# Altium schematic sheet SWITCHING (CONT).SchDoc
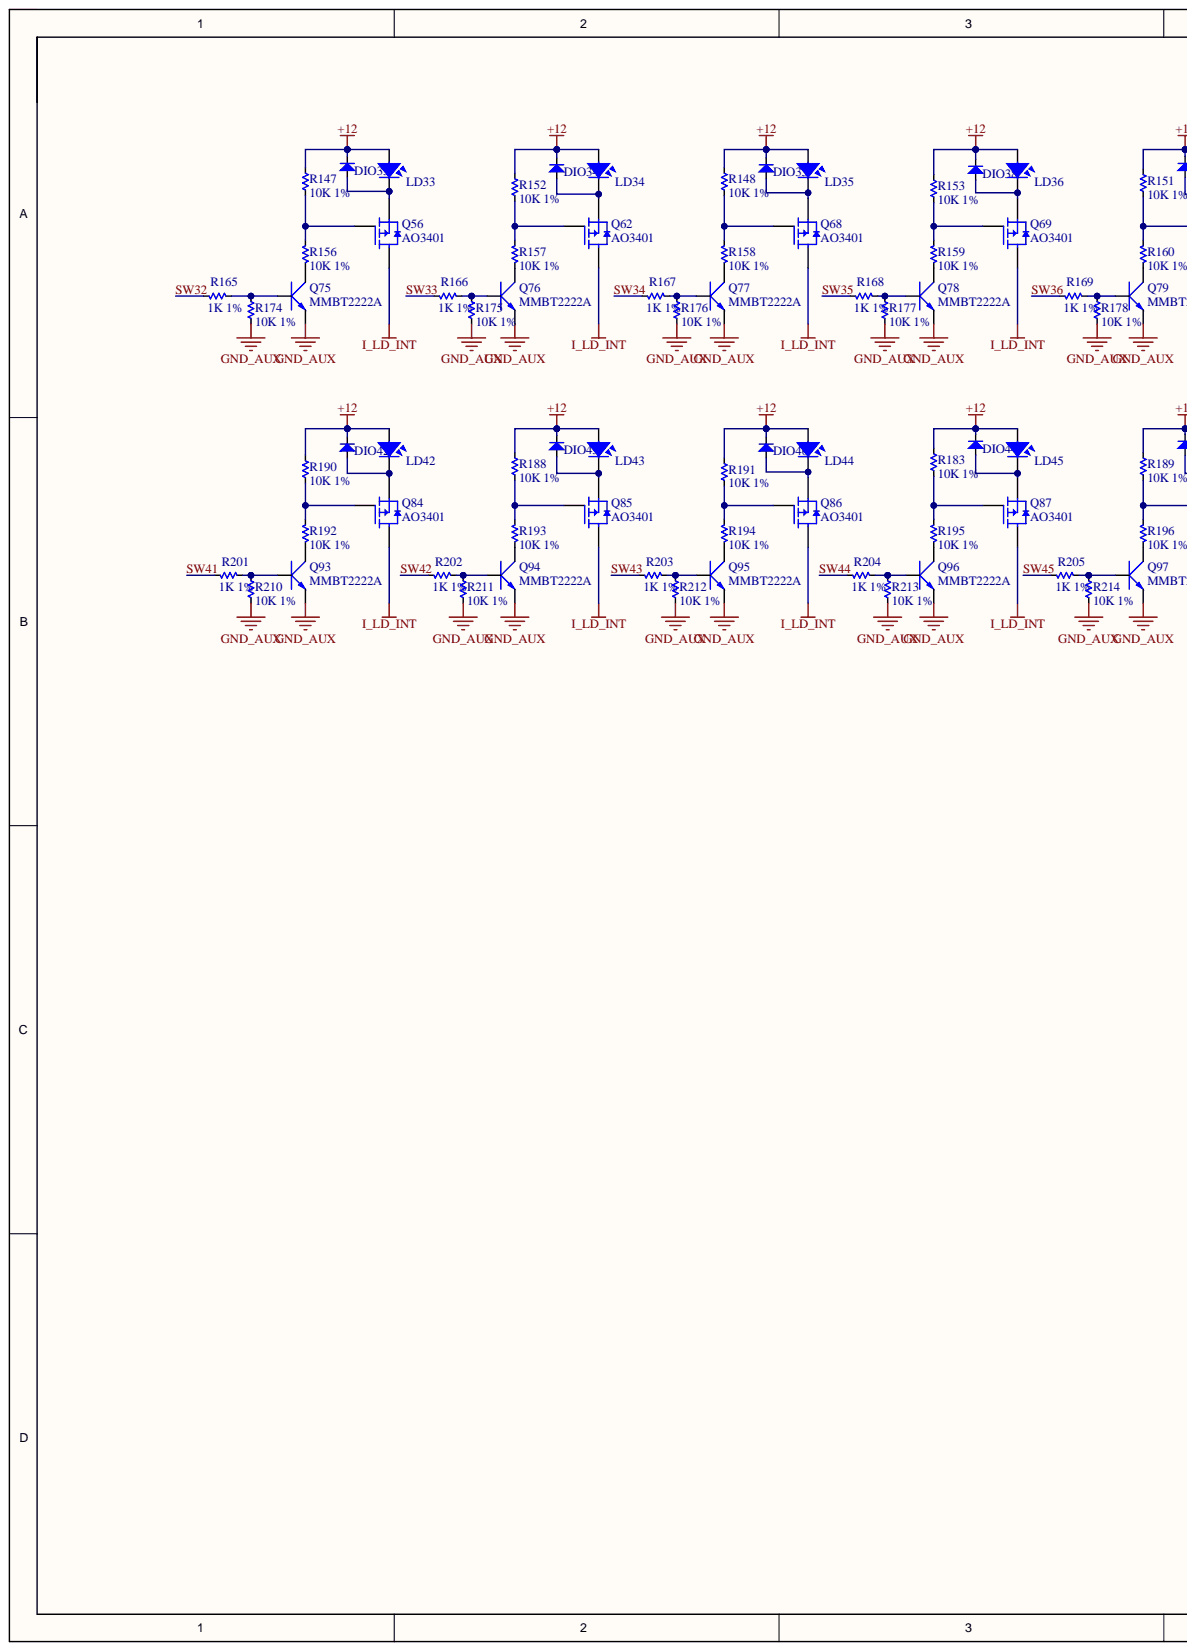
[diagram p0: SWITCHING (CONT).SchDoc, left]
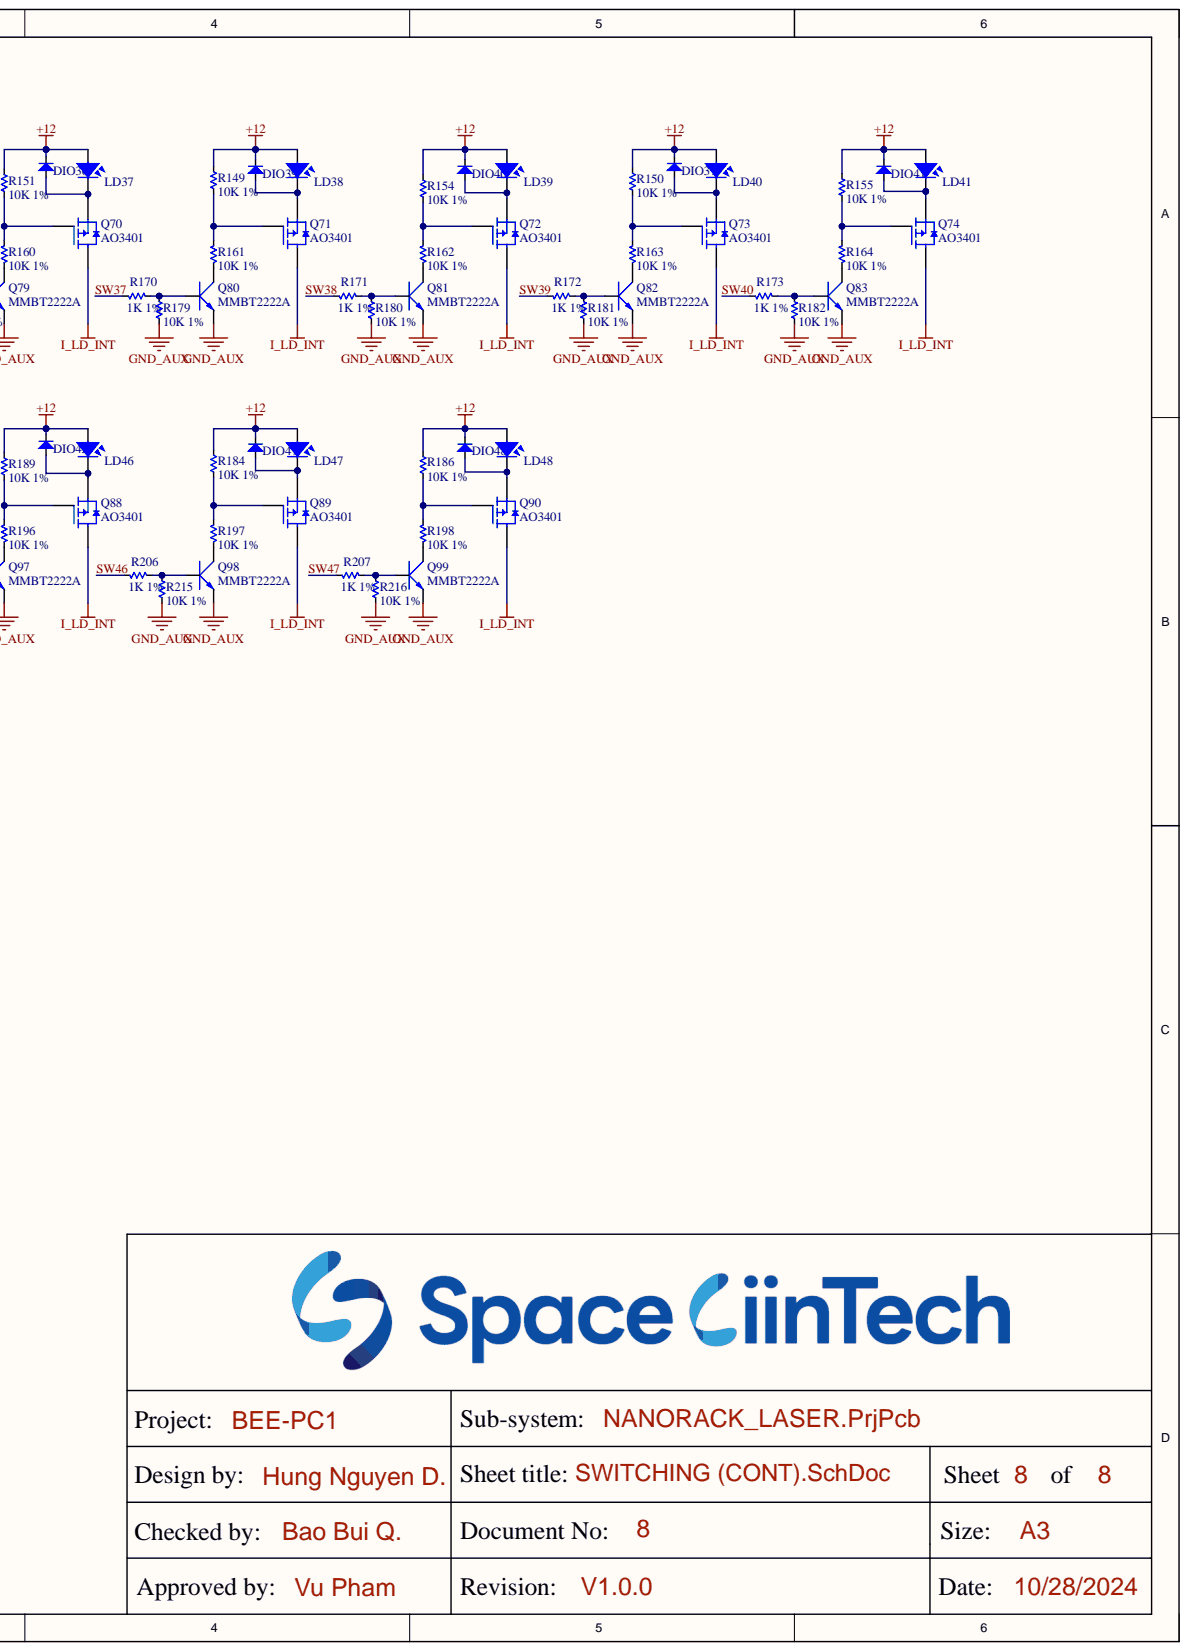
[diagram p1: SWITCHING (CONT).SchDoc, right]

## Parts
DIO33  Diode SMD  [SOD23]  (value not drawn)
DIO34  Diode SMD  [SOD23]  (value not drawn)
DIO35  Diode SMD  [SOD23]  (value not drawn)
DIO36  Diode SMD  [SOD23]  (value not drawn)
DIO37  Diode SMD  [SOD23]  (value not drawn)
DIO38  Diode SMD  [SOD23]  (value not drawn)
DIO39  Diode SMD  [SOD23]  (value not drawn)
DIO40  Diode SMD  [SOD23]  (value not drawn)
DIO41  Diode SMD  [SOD23]  (value not drawn)
DIO42  Diode SMD  [SOD23]  (value not drawn)
DIO43  Diode SMD  [SOD23]  (value not drawn)
DIO44  Diode SMD  [SOD23]  (value not drawn)
DIO45  Diode SMD  [SOD23]  (value not drawn)
DIO46  Diode SMD  [SOD23]  (value not drawn)
DIO47  Diode SMD  [SOD23]  (value not drawn)
DIO48  Diode SMD  [SOD23]  (value not drawn)
LD33  LaserDiode  [LASER]  (value not drawn)
LD34  LaserDiode  [LASER]  (value not drawn)
LD35  LaserDiode  [LASER]  (value not drawn)
LD36  LaserDiode  [LASER]  (value not drawn)
LD37  LaserDiode  [LASER]  (value not drawn)
LD38  LaserDiode  [LASER]  (value not drawn)
LD39  LaserDiode  [LASER]  (value not drawn)
LD40  LaserDiode  [LASER]  (value not drawn)
LD41  LaserDiode  [LASER]  (value not drawn)
LD42  LaserDiode  [LASER]  (value not drawn)
LD43  LaserDiode  [LASER]  (value not drawn)
LD44  LaserDiode  [LASER]  (value not drawn)
LD45  LaserDiode  [LASER]  (value not drawn)
LD46  LaserDiode  [LASER]  (value not drawn)
LD47  LaserDiode  [LASER]  (value not drawn)
LD48  LaserDiode  [LASER]  (value not drawn)
Q56  AO3401  [SOT23-123]
Q62  AO3401  [SOT23-123]
Q68  AO3401  [SOT23-123]
Q69  AO3401  [SOT23-123]
Q70  AO3401  [SOT23-123]
Q71  AO3401  [SOT23-123]
Q72  AO3401  [SOT23-123]
Q73  AO3401  [SOT23-123]
Q74  AO3401  [SOT23-123]
Q75  MMBT2222A  [SOT23-132]
Q76  MMBT2222A  [SOT23-132]
Q77  MMBT2222A  [SOT23-132]
Q78  MMBT2222A  [SOT23-132]
Q79  MMBT2222A  [SOT23-132]
Q80  MMBT2222A  [SOT23-132]
Q81  MMBT2222A  [SOT23-132]
Q82  MMBT2222A  [SOT23-132]
Q83  MMBT2222A  [SOT23-132]
Q84  AO3401  [SOT23-123]
Q85  AO3401  [SOT23-123]
Q86  AO3401  [SOT23-123]
Q87  AO3401  [SOT23-123]
Q88  AO3401  [SOT23-123]
Q89  AO3401  [SOT23-123]
Q90  AO3401  [SOT23-123]
Q93  MMBT2222A  [SOT23-132]
Q94  MMBT2222A  [SOT23-132]
Q95  MMBT2222A  [SOT23-132]
Q96  MMBT2222A  [SOT23-132]
Q97  MMBT2222A  [SOT23-132]
Q98  MMBT2222A  [SOT23-132]
Q99  MMBT2222A  [SOT23-132]
R147  10K 1%  [RES-SMD-0603]
R148  10K 1%  [RES-SMD-0603]
R149  10K 1%  [RES-SMD-0603]
R150  10K 1%  [RES-SMD-0603]
R151  10K 1%  [RES-SMD-0603]
R152  10K 1%  [RES-SMD-0603]
R153  10K 1%  [RES-SMD-0603]
R154  10K 1%  [RES-SMD-0603]
R155  10K 1%  [RES-SMD-0603]
R156  10K 1%  [RES-SMD-0603]
R157  10K 1%  [RES-SMD-0603]
R158  10K 1%  [RES-SMD-0603]
R159  10K 1%  [RES-SMD-0603]
R160  10K 1%  [RES-SMD-0603]
R161  10K 1%  [RES-SMD-0603]
R162  10K 1%  [RES-SMD-0603]
R163  10K 1%  [RES-SMD-0603]
R164  10K 1%  [RES-SMD-0603]
R165  1K 1%  [RES-SMD-0603]
R166  1K 1%  [RES-SMD-0603]
R167  1K 1%  [RES-SMD-0603]
R168  1K 1%  [RES-SMD-0603]
R169  1K 1%  [RES-SMD-0603]
R170  1K 1%  [RES-SMD-0603]
R171  1K 1%  [RES-SMD-0603]
R172  1K 1%  [RES-SMD-0603]
R173  1K 1%  [RES-SMD-0603]
R174  10K 1%  [RES-SMD-0603]
R175  10K 1%  [RES-SMD-0603]
R176  10K 1%  [RES-SMD-0603]
R177  10K 1%  [RES-SMD-0603]
R178  10K 1%  [RES-SMD-0603]
R179  10K 1%  [RES-SMD-0603]
R180  10K 1%  [RES-SMD-0603]
R181  10K 1%  [RES-SMD-0603]
R182  10K 1%  [RES-SMD-0603]
R183  10K 1%  [RES-SMD-0603]
R184  10K 1%  [RES-SMD-0603]
R186  10K 1%  [RES-SMD-0603]
R188  10K 1%  [RES-SMD-0603]
R189  10K 1%  [RES-SMD-0603]
R190  10K 1%  [RES-SMD-0603]
R191  10K 1%  [RES-SMD-0603]
R192  10K 1%  [RES-SMD-0603]
R193  10K 1%  [RES-SMD-0603]
R194  10K 1%  [RES-SMD-0603]
R195  10K 1%  [RES-SMD-0603]
R196  10K 1%  [RES-SMD-0603]
R197  10K 1%  [RES-SMD-0603]
R198  10K 1%  [RES-SMD-0603]
R201  1K 1%  [RES-SMD-0603]
R202  1K 1%  [RES-SMD-0603]
R203  1K 1%  [RES-SMD-0603]
R204  1K 1%  [RES-SMD-0603]
R205  1K 1%  [RES-SMD-0603]
R206  1K 1%  [RES-SMD-0603]
R207  1K 1%  [RES-SMD-0603]
R210  10K 1%  [RES-SMD-0603]
R211  10K 1%  [RES-SMD-0603]
R212  10K 1%  [RES-SMD-0603]
R213  10K 1%  [RES-SMD-0603]
R214  10K 1%  [RES-SMD-0603]
R215  10K 1%  [RES-SMD-0603]
R216  10K 1%  [RES-SMD-0603]

## Nets
+12: DIO33.2 DIO34.2 DIO35.2 DIO36.2 DIO37.2 DIO38.2 DIO39.2 DIO40.2 DIO41.2 DIO42.2 DIO43.2 DIO44.2 DIO45.2 DIO46.2 DIO47.2 DIO48.2 LD33.1 LD34.1 LD35.1 LD36.1 LD37.1 LD38.1 LD39.1 LD40.1 LD41.1 LD42.1 LD43.1 LD44.1 LD45.1 LD46.1 LD47.1 LD48.1 R147.1 R148.1 R149.1 R150.1 R151.1 R152.1 R153.1 R154.1 R155.1 R183.1 R184.1 R186.1 R188.1 R189.1 R190.1 R191.1
GND_AUX: Q75.2 Q76.2 Q77.2 Q78.2 Q79.2 Q80.2 Q81.2 Q82.2 Q83.2 Q93.2 Q94.2 Q95.2 Q96.2 Q97.2 Q98.2 Q99.2 R174.2 R175.2 R176.2 R177.2 R178.2 R179.2 R180.2 R181.2 R182.2 R210.2 R211.2 R212.2 R213.2 R214.2 R215.2 R216.2
I_LD_INT: Q56.2 Q62.2 Q68.2 Q69.2 Q70.2 Q71.2 Q72.2 Q73.2 Q74.2 Q84.2 Q85.2 Q86.2 Q87.2 Q88.2 Q89.2 Q90.2
SW32: R165.1
SW33: R166.1
SW34: R167.1
SW35: R168.1
SW36: R169.1
SW37: R170.1
SW38: R171.1
SW39: R172.1
SW40: R173.1
SW41: R201.1
SW42: R202.1
SW43: R203.1
SW44: R204.1
SW45: R205.1
SW46: R206.1
SW47: R207.1
NetDIO33_1: DIO33.1 LD33.3 Q56.3
NetDIO34_1: DIO34.1 LD34.3 Q62.3
NetDIO35_1: DIO35.1 LD35.3 Q68.3
NetDIO36_1: DIO36.1 LD37.3 Q70.3
NetDIO37_1: DIO37.1 LD40.3 Q73.3
NetDIO38_1: DIO38.1 LD36.3 Q69.3
NetDIO39_1: DIO39.1 LD38.3 Q71.3
NetDIO40_1: DIO40.1 LD39.3 Q72.3
NetDIO41_1: DIO41.1 LD41.3 Q74.3
NetDIO42_1: DIO42.1 LD42.3 Q84.3
NetDIO43_1: DIO43.1 LD43.3 Q85.3
NetDIO44_1: DIO44.1 LD45.3 Q87.3
NetDIO45_1: DIO45.1 LD46.3 Q88.3
NetDIO46_1: DIO46.1 LD44.3 Q86.3
NetDIO47_1: DIO47.1 LD47.3 Q89.3
NetDIO48_1: DIO48.1 LD48.3 Q90.3
NetQ56_1: Q56.1 R147.2 R156.2
NetQ62_1: Q62.1 R152.2 R157.2
NetQ68_1: Q68.1 R148.2 R158.2
NetQ69_1: Q69.1 R153.2 R159.2
NetQ70_1: Q70.1 R151.2 R160.2
NetQ71_1: Q71.1 R149.2 R161.2
NetQ72_1: Q72.1 R154.2 R162.2
NetQ73_1: Q73.1 R150.2 R163.2
NetQ74_1: Q74.1 R155.2 R164.2
NetQ75_1: Q75.1 R165.2 R174.1
NetQ75_3: Q75.3 R156.1
NetQ76_1: Q76.1 R166.2 R175.1
NetQ76_3: Q76.3 R157.1
NetQ77_1: Q77.1 R167.2 R176.1
NetQ77_3: Q77.3 R158.1
NetQ78_1: Q78.1 R168.2 R177.1
NetQ78_3: Q78.3 R159.1
NetQ79_1: Q79.1 R169.2 R178.1
NetQ79_3: Q79.3 R160.1
NetQ80_1: Q80.1 R170.2 R179.1
NetQ80_3: Q80.3 R161.1
NetQ81_1: Q81.1 R171.2 R180.1
NetQ81_3: Q81.3 R162.1
NetQ82_1: Q82.1 R172.2 R181.1
NetQ82_3: Q82.3 R163.1
NetQ83_1: Q83.1 R173.2 R182.1
NetQ83_3: Q83.3 R164.1
NetQ84_1: Q84.1 R190.2 R192.2
NetQ85_1: Q85.1 R188.2 R193.2
NetQ86_1: Q86.1 R191.2 R194.2
NetQ87_1: Q87.1 R183.2 R195.2
NetQ88_1: Q88.1 R189.2 R196.2
NetQ89_1: Q89.1 R184.2 R197.2
NetQ90_1: Q90.1 R186.2 R198.2
NetQ93_1: Q93.1 R201.2 R210.1
NetQ93_3: Q93.3 R192.1
NetQ94_1: Q94.1 R202.2 R211.1
NetQ94_3: Q94.3 R193.1
NetQ95_1: Q95.1 R203.2 R212.1
NetQ95_3: Q95.3 R194.1
NetQ96_1: Q96.1 R204.2 R213.1
NetQ96_3: Q96.3 R195.1
NetQ97_1: Q97.1 R205.2 R214.1
NetQ97_3: Q97.3 R196.1
NetQ98_1: Q98.1 R206.2 R215.1
NetQ98_3: Q98.3 R197.1
NetQ99_1: Q99.1 R207.2 R216.1
NetQ99_3: Q99.3 R198.1

## Library data of the parts
DIO33-DIO48 = Diode SMD: Default Diode; Code - JEDEC: DO-214-AB; Value: 1N5007; Component Kind: Standard; Library Name: Library.SchLib; Published: 8-Jun-2000; Revision: July-2002: Re-released for DXP Platform.; Publisher: Altium Limited
LD33-LD48 = LD: Component Kind: Standard; Library Name: Library.SchLib
Q56 Q62 Q68-Q74 Q84-Q90 = FET-P: Component Kind: Standard; Library Name: Library.SchLib
Q75-Q83 Q93-Q99 = 2SC1815: SOT23-3; Value: C1815; Component Kind: Standard; Library Name: Library.SchLib
R147-R184 R186 R188-R198 R201-R207 R210-R216 = Res-SMD: 0603; Manufacturer: Generic Components; Class: Passive; Component Kind: Standard; Library Name: Library.SchLib; Published: 08/06/2000; Publisher: Altium Hobart Technology Centre
